# Altium schematic sheet Main_Sch.SchDoc
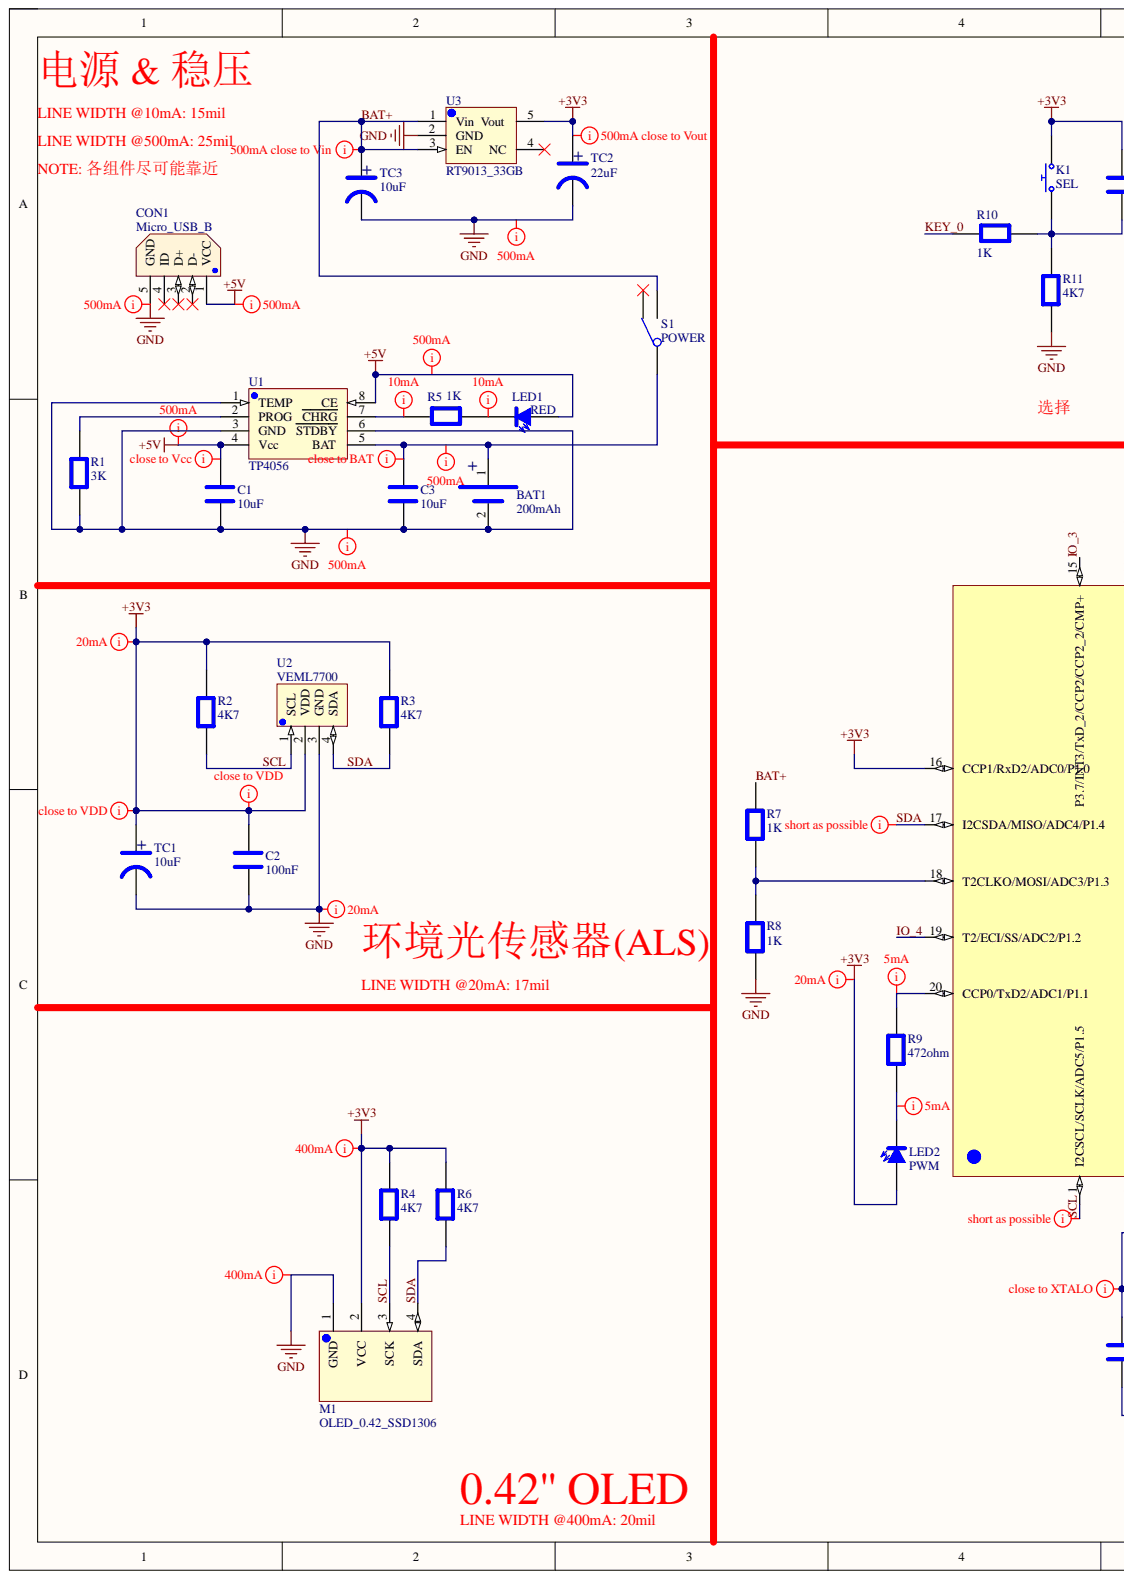
[diagram p0: Main_Sch.SchDoc, left]
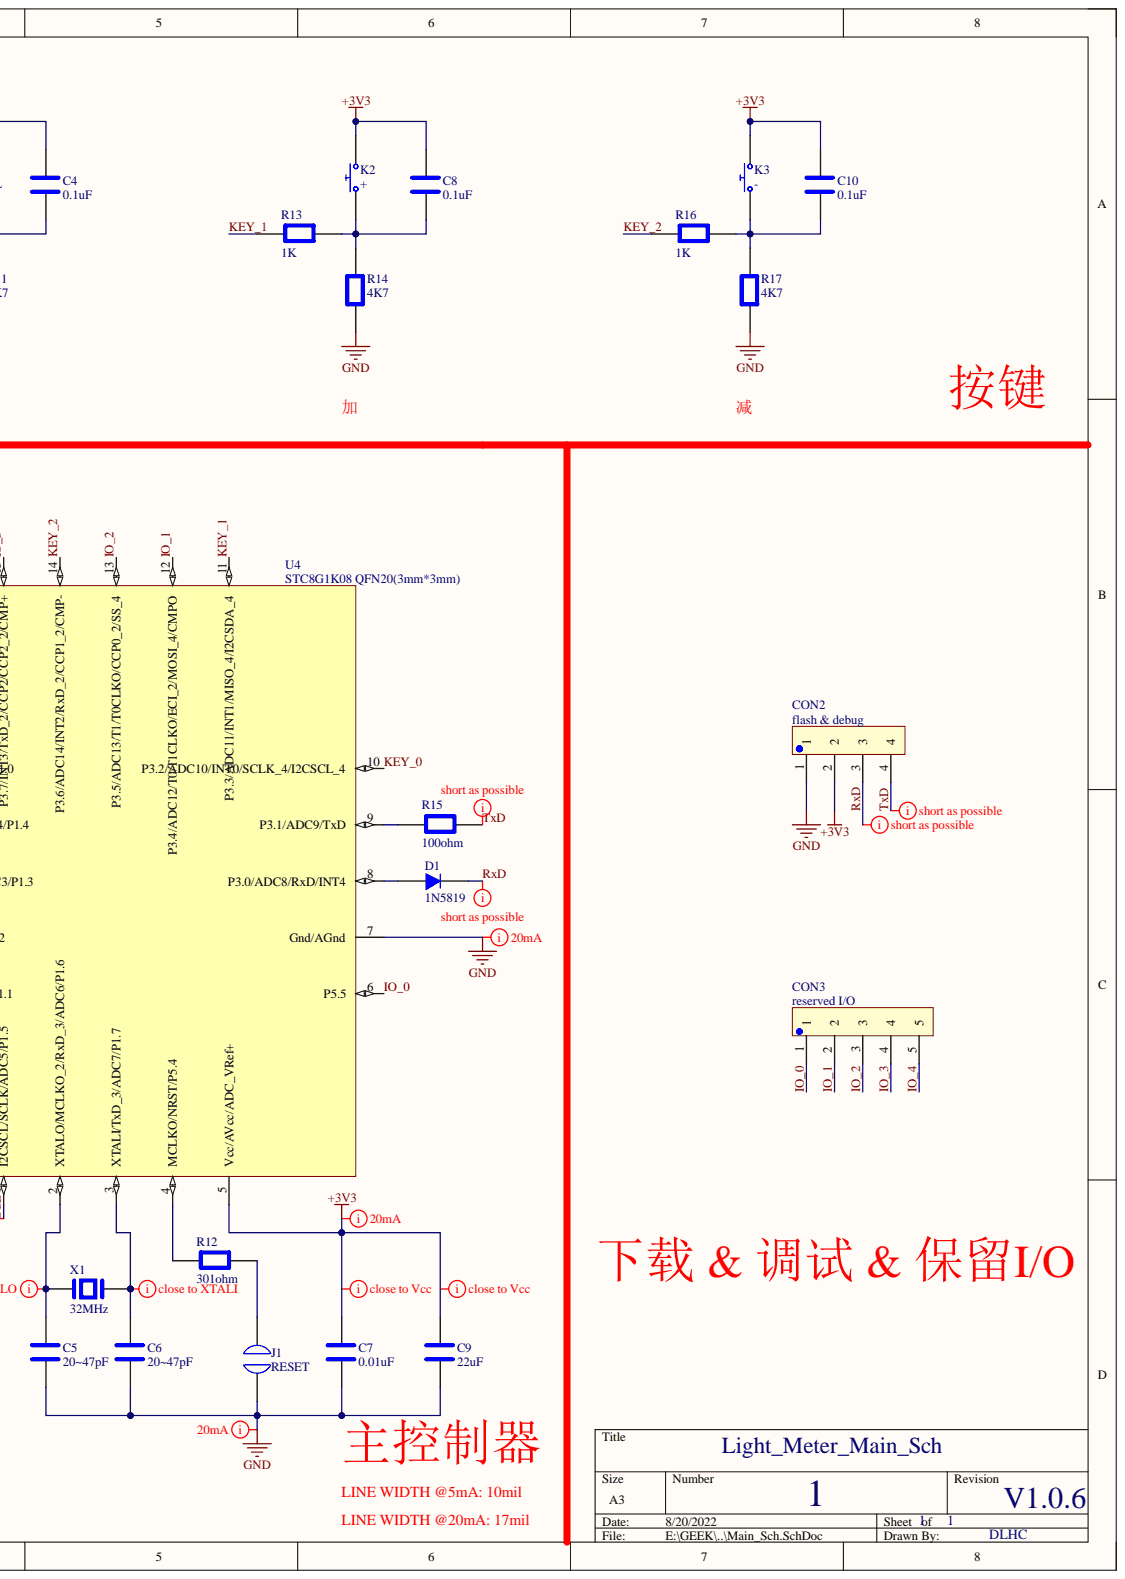
[diagram p1: Main_Sch.SchDoc, right]

## Parts
BAT1  200mAh  [Li-lon]
C1  10uF  [CAPACITOR]
C2  100nF  [CAPACITOR]
C3  10uF  [CAPACITOR]
C4  0.1uF  [CAPACITOR]
C5  20~47pF  [CAPACITOR]
C6  20~47pF  [CAPACITOR]
C7  0.01uF  [CAPACITOR]
C8  0.1uF  [CAPACITOR]
C9  22uF  [CAPACITOR]
C10  0.1uF  [CAPACITOR]
CON1  Micro_USB_B  [Micro_USB_B]
CON2  flash & debug  [PIN_HEADER_4]
CON3  reserved I/O  [PIN_HEADER_5]
D1  1N5819  [DIODE]
J1  RESET  [JUMPER]
K1  SEL  [KEY]
K2  +  [KEY]
K3  -  [KEY]
LED1  RED  [LED]
LED2  PWM  [LED]
M1  OLED_0.42_SSD1306  [OLED_0.42]
R1  3K  [RESISTER]
R2  4K7  [RESISTER]
R3  4K7  [RESISTER]
R4  4K7  [RESISTER]
R5  1K  [RESISTER]
R6  4K7  [RESISTER]
R7  1K  [RESISTER]
R8  1K  [RESISTER]
R9  472ohm  [RESISTER]
R10  1K  [RESISTER]
R11  4K7  [RESISTER]
R12  301ohm  [RESISTER]
R13  1K  [RESISTER]
R14  4K7  [RESISTER]
R15  100ohm  [RESISTER]
R16  1K  [RESISTER]
R17  4K7  [RESISTER]
S1  POWER  [SWITCH]
TC1  10uF  [CAPACITOR_T]
TC2  22uF  [CAPACITOR_T]
TC3  10uF  [CAPACITOR_T]
U1  TP4056  [TP4056]
U2  VEML7700  [VEML7700]
U3  RT9013_33GB  [RT9013]
U4  STC8G1K08 QFN20(3mm*3mm)  [STC8G1K08]
X1  32MHz  [XTAL]

## Nets
+3V3: C2.2 C4.2 C7.2 C8.2 C9.2 C10.2 CON2.2 K1.2 K2.2 K3.2 LED2.1 M1.2(VCC) R2.2 R3.2 R4.2 R6.2 TC1.1 TC2.1 U2.2(VDD) U3.5(Vout) U4.5(Vcc/AVcc/ADC_VRef+) U4.16(CCP1/RxD2/ADC0/P1.0)
+5V: C1.2 CON1.1(VCC) LED1.1 U1.4(Vcc) U1.8(CE)
GND: BAT1.2 C1.1 C2.1 C3.1 C5.1 C6.1 C7.1 C9.1 CON1.5(GND) CON2.1 J1.1 M1.1(GND) R1.1 R8.1 R11.1 R14.1 R17.1 TC1.2 TC2.2 TC3.2 U1.1(TEMP) U1.3(GND) U1.6(STDBY) U2.3(GND) U3.2(GND) U4.7(Gnd/AGnd)
BAT+: R7.2 S1.1 TC3.1 U3.1(Vin) U3.3(EN)
IO_0: CON3.1 U4.6(P5.5)
IO_1: CON3.2 U4.12(P3.4/ADC12/T0/T1CLKO/ECI_2/MOSI_4/CMPO)
IO_2: CON3.3 U4.13(P3.5/ADC13/T1/T0CLKO/CCP0_2/SS_4)
IO_3: CON3.4 U4.15(P3.7/INT3/TxD_2/CCP2/CCP2_2/CMP+)
IO_4: CON3.5 U4.19(T2/ECI/SS/ADC2/P1.2)
KEY_0: R10.1 U4.10(P3.2/ADC10/INT0/SCLK_4/I2CSCL_4)
KEY_1: R13.1 U4.11(P3.3/ADC11/INT1/MISO_4/I2CSDA_4)
KEY_2: R16.1 U4.14(P3.6/ADC14/INT2/RxD_2/CCP1_2/CMP-)
RxD: CON2.3 D1.2
SCL: M1.3(SCK) R2.1 R4.1 U2.1(SCL) U4.1(I2CSCL/SCLK/ADC5/P1.5)
SDA: M1.4(SDA) R3.1 R6.1 U2.4(SDA) U4.17(I2CSDA/MISO/ADC4/P1.4)
TxD: CON2.4 R15.2
NetBAT1_1: BAT1.1 C3.2 S1.2 U1.5(BAT)
NetC4_1: C4.1 K1.1 R10.2 R11.2
NetC5_2: C5.2 U4.2(XTALO/MCLKO_2/RxD_3/ADC6/P1.6) X1.1
NetC6_2: C6.2 U4.3(XTALI/TxD_3/ADC7/P1.7) X1.2
NetC8_1: C8.1 K2.1 R13.2 R14.2
NetC10_1: C10.1 K3.1 R16.2 R17.2
NetD1_1: D1.1 U4.8(P3.0/ADC8/RxD/INT4)
NetJ1_2: J1.2 R12.2
NetLED1_2: LED1.2 R5.2
NetLED2_2: LED2.2 R9.1
NetR1_2: R1.2 U1.2(PROG)
NetR5_1: R5.1 U1.7(CHRG)
NetR7_1: R7.1 R8.2 U4.18(T2CLKO/MOSI/ADC3/P1.3)
NetR9_2: R9.2 U4.20(CCP0/TxD2/ADC1/P1.1)
NetR12_1: R12.1 U4.4(MCLKO/NRST/P5.4)
NetR15_1: R15.1 U4.9(P3.1/ADC9/TxD)

Not connected: CON1 2(D-) 3(D+) 4(ID); S1 3; U3 4(NC)

## Library data of the parts
BAT1 = Li_lon: 3.7V ~ 4.2V rechargeable Li-lon battery; Component Kind: Standard; Library Name: Schlib.SchLib
C1-C10 = CAPACITOR: General capacitor; Component Kind: Standard; Library Name: Schlib.SchLib
CON1 = Micro_USB_B: Micro USB B type connnector; Component Kind: Standard; Library Name: Schlib.SchLib
CON2 = PIN_HEADER_4: 2.54mm gap, 4 pin, general connector; Component Kind: Standard; Library Name: Schlib.SchLib
CON3 = PIN_HEADER_5: 2.54mm gap, 5 pin, general connector; Component Kind: Standard; Library Name: Schlib.SchLib
D1 = DIODE: General diode; Component Kind: Standard; Library Name: Schlib.SchLib
J1 = JUMPER: General jumper; Component Kind: Standard; Library Name: Schlib.SchLib
K1-K3 = KEY: General push button key; Component Kind: Standard; Library Name: Schlib.SchLib
LED1 LED2 = LED: General LED; Component Kind: Standard; Library Name: Schlib.SchLib
M1 = OLED_0.42: 0.42' OLED(72*40 dots) with SSD1306 display controller; Component Kind: Standard; Library Name: Schlib.SchLib
R1-R17 = RESISTER: Gereral resister; Component Kind: Standard; Library Name: Schlib.SchLib
S1 = SWITCH: 50000cycles, 0.5A, 30mohm, 100Mohm, power switch; Component Kind: Standard; Library Name: Schlib.SchLib
TC1-TC3 = CAPACITOR_T: General tantalum capacitor; Component Kind: Standard; Library Name: Schlib.SchLib
U1 = TP4056: TP4056 ESOP-8 Li-lon battery charge IC; Component Kind: Standard; Library Name: Schlib.SchLib
U2 = VEML7700: High Accuracy Ambient Light Sensor With I2C Interface; Component Kind: Standard; Library Name: Schlib.SchLib
U3 = RT9013: 500mA, Low Dropout, Low Noise Ultra-Fast Without Bypass Capacitor CMOS LDO Regulator; Component Kind: Standard; Library Name: Schlib.SchLib
U4 = STC8G1K08: STC8G1K08 8051 based MCU, QFN20 3mm*3mm package; Component Kind: Standard; Library Name: Schlib.SchLib
X1 = XTAL: External Crystal Oscillator, No power  supply; Component Kind: Standard; Library Name: Schlib.SchLib
